# Altium schematic sheet POWER_REV2.SchDoc(POWER_REV2)
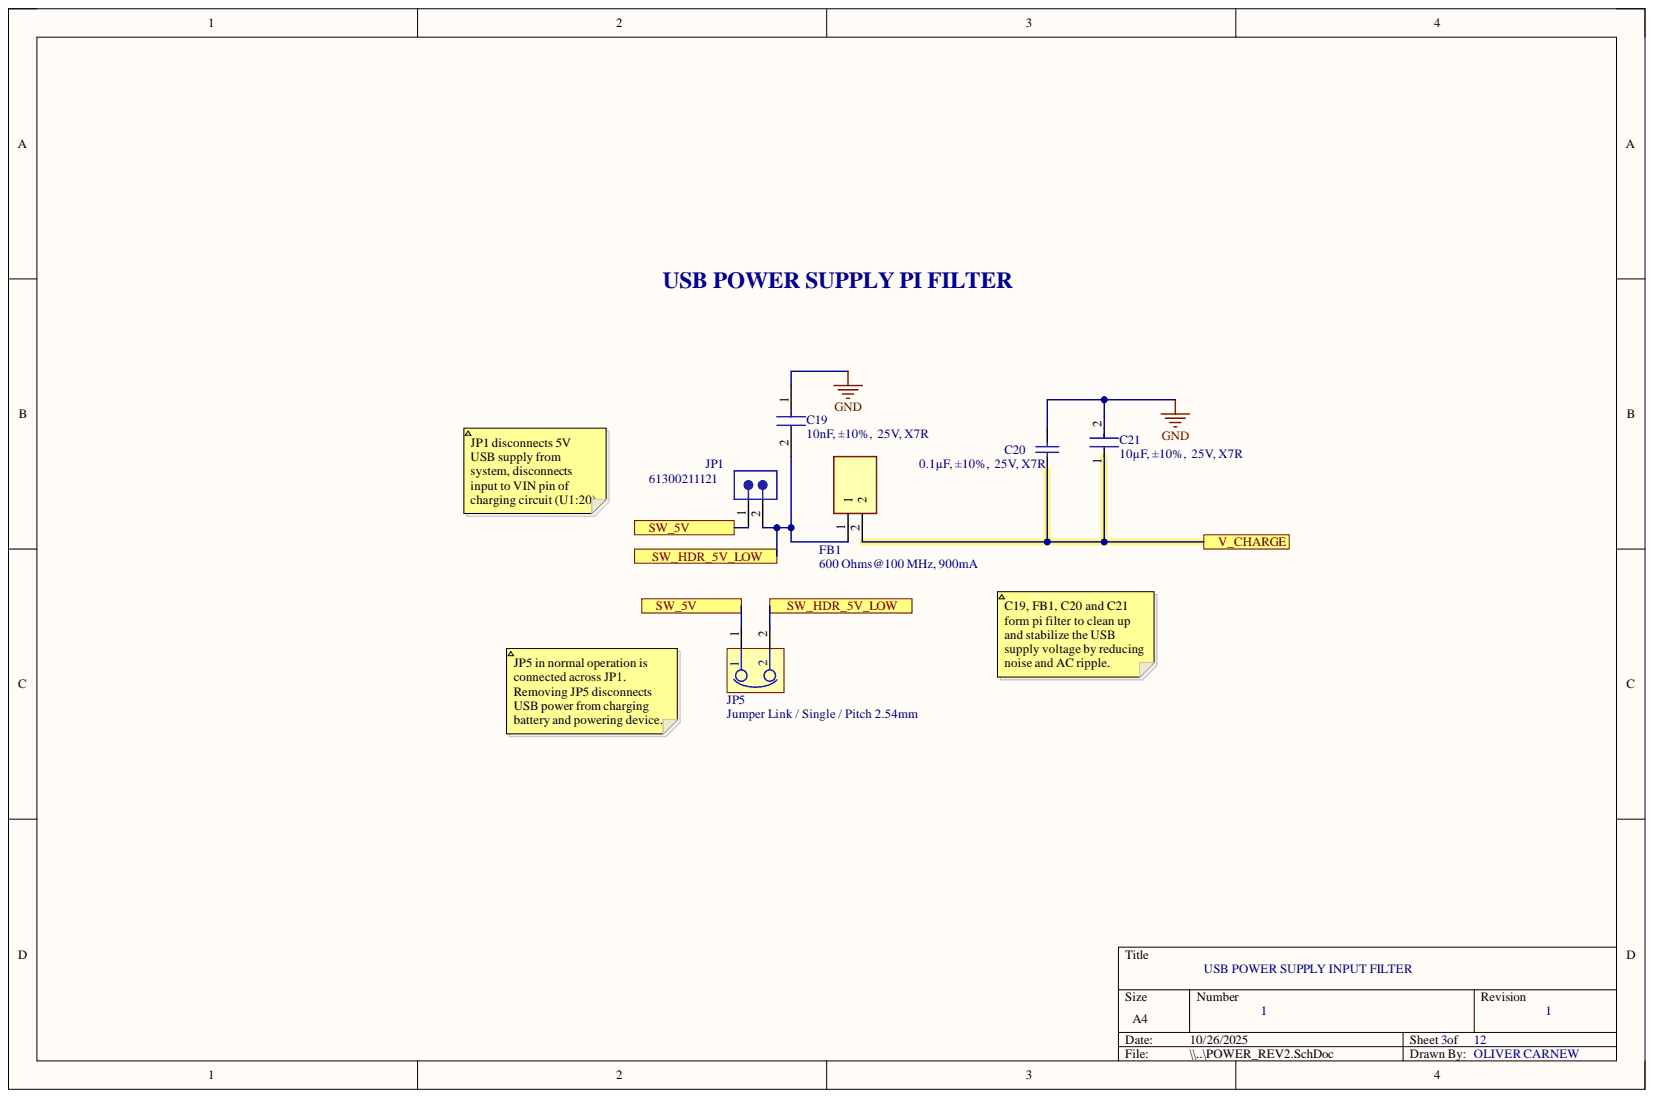
[diagram p0: POWER_REV2.SchDoc]

## Parts
C19  10nF, ±10%,  25V, X7R  [C0603]
C20  0.1µF, ±10%,  25V, X7R  Dielectric: X7R  [CAPC1608X90X35ML10T15]
C21  10µF, ±10%,  25V, X7R  [FP-CL21B106KOQNNNE-MFG]
FB1  600 Ohms@100 MHz, 900mA  [BEADC1608X90N]
JP1  61300211121  [61300211121]
JP5  Jumper Link / Single / Pitch 2.54mm

## Ports
SW_5V, SW_HDR_5V_LOW, V_CHARGE

## Nets
GND: C19.1 C20.2 C21.2
SW_5V: JP1.1 JP5.1 ; port SW_5V
SW_HDR_5V_LOW: C19.2 FB1.1 JP1.2 JP5.2 ; port SW_HDR_5V_LOW
V_CHARGE: C20.1 C21.1 FB1.2 ; port V_CHARGE

## Library data of the parts
C19 = C0603C103K4RECTU: Capacitor 10000 pF ±10% 16V Ceramic Capacitor X7R 0603 (1608 Metric) ETSG 64-12-01 C0603C103K4RECTU; Manufacturer_Name: KEMET; Arrow Part Number: C0603C103K4RECTU; Arrow Price/Stock: https://www.arrow.com/en/products/c0603c103k4rectu/kemet-corporation?region=nac; Component Kind: Standard; Datasheet Link: https://content.kemet.com/datasheets/KEM_C1090_X7R_ESD.pdf; Height: 0.87mm; Manufacturer_Part_Number: C0603C103K4RECTU; Mouser Part Number: 80-C0603C103K4RECTU; Mouser Price/Stock: https://www.mouser.co.uk/ProductDetail/KEMET/C0603C103K4RECTU?qs=55YtniHzbhDzGaFFpCm8vg%3D%3D
C20 = CMP-2100-03643-1: Chip Capacitor, 0.1 uF, +/- 10%, 50 V, -55 to 125 degC, 0603 (1608 Metric), RoHS, Tape and Reel; Manufacturer: Murata; Capacitance: 100nF; Dielectric Material: Ceramic; Insulation Resistance: 10GR; Voltage: 50V; Case Code (Imperial): 0603; Case Code (Metric): 1608; Case/Package: 0603; Component Kind: Standard; Contact Plating: Tin; Datasheet URL: http://psearch.en.murata.com/capacitor/product/GRM188R71H104KA93%23.pdf; Datasheet Version: 42675.0; Depth: 0.8mm; Height: 0.8mm; Lead Free: LeadFree; Length: 1.6mm; Library Name: Altium Content Vault; Manufacturer URL: http://www.murata.com/; Material: Ceramic; Max Operating Temperature: 125degC; Min Operating Temperature: -55degC; Mount: SurfaceMount; Number of Pins: 2; Package Description: 2-Pin Surface Mount Device, Body 1.6 x 0.8 mm; Package Quantity: 1; Package Reference: 0603; Packaging: TapeandReel; Radiation Hardening: No; REACH SVHC: NoSVHC; RoHSCompliant: true; Termination: SMD/SMT; Thickness: 0.035inch; Tolerance: 10%; Voltage Rating: 50V; Voltage Rating (DC): 50V; Width: 0.8mm
C21 = CMP-2000-06106-2: CAP CER 10UF 16V X7R 0805 CL21B106KOQNNNE; Manufacturer: Samsung Electro-Mechanics America, Inc; Material: Ceramic; Temperature Coefficient: 15%; Automotive: No; Capacitance: 10uF; Category: Cap; Component Kind: Standard; ComponentLink2Description: Datasheet; ComponentLink2URL: http://www.samsungsem.com/servlet/FileDownload?path=productsearch&sname=CL21B106KOQNNNE.pdf&oname=CL21B106KOQNNNE.pdf; Device Class L1: Passive Components; Device Class L2: Capacitors; Device Class L3: Ceramic Capacitors; Digikey Description: CAP CER 10UF 16V X7R 0805; Height: 1.4mm; Ipc Land Pattern Name: CAPC200125X125; Lead Free: Yes; Library Name: Altium Content Vault; Manufacturer Part Number: CL21B106KOQNNNE; Octopart: https://octopart.com/cl21b106koqnnne-samsung-19832289; Package: CL21B106KOQNNNE; RoHS: TRUE; Temperature: -55°C to +125°C; Temperature Characteristic: X7R; Temperature Range High: +125°C; Temperature Range Low: -55°C; Tolerance: 10%; Value: 10uF; Voltage Rating: 16V
FB1 = FBMH1608HM601-T: 600 Ohms @ 100 MHz 1 Power Line Ferrite Bead 0603 (1608 Metric) 900mA 170mOhm; Manufacturer_Name: TAIYO YUDEN; Arrow Part Number: FBMH1608HM601-T; Arrow Price/Stock: https://www.arrow.com/en/products/fbmh1608hm601-t/taiyo-yuden; Component Kind: Standard; Datasheet Link: https://componentsearchengine.com/Datasheets/2/FBMH1608HM601-T.pdf; Height: 0.9mm; Manufacturer_Part_Number: FBMH1608HM601-T; Mouser Part Number: 963-FBMH1608HM601-T; Mouser Price/Stock: https://www.mouser.co.uk/ProductDetail/TAIYO-YUDEN/FBMH1608HM601-T?qs=I6KAKw0tg2y6O4cu0afp%252BA%3D%3D; Library Name: DANCE_LIB_REV2.SchLib
JP1 = CMP-1712-00002-6: NexarPartId: 20081794; Connector Type: Male Pin; Contact Resistance: 20mΩ; Current Rating: 3A; Gender: Male; Number of Contacts: 2; Operating Supply Voltage: 250V; Pitch: 2.54mm; Color: Black; Component Kind: Standard; Contact Gender: Male; Contact Material: Copper; Contact Mating Length: 5.9944mm; Contact Plating: Gold; Height: 8.94mm; Housing Material: PBT; Lead Free: Lead Free; Lead Pitch: 2.54mm; Library Name: Altium Content Vault; Max Operating Temperature: 125°C; Min Operating Temperature: -40°C; Mount: Through Hole; Number of Pins: 2; Number of Positions: 2; Number of Rows: 1; Orientation: Vertical; Packaging: Bulk; REACH SVHC: No SVHC; RoHS: Compliant; Termination: Through Hole; Voltage Rating: 250V
JP5 = jumper_2.54mm: CONNECTOR : PCB Jumper Link / Single / Pitch 2.54mm / Black /shunt.  01-96-02; Component Kind: Standard; Library Name: DANCE_LIB_REV2.SchLib
